# Altium schematic sheet SOC.SchDoc(SOC)
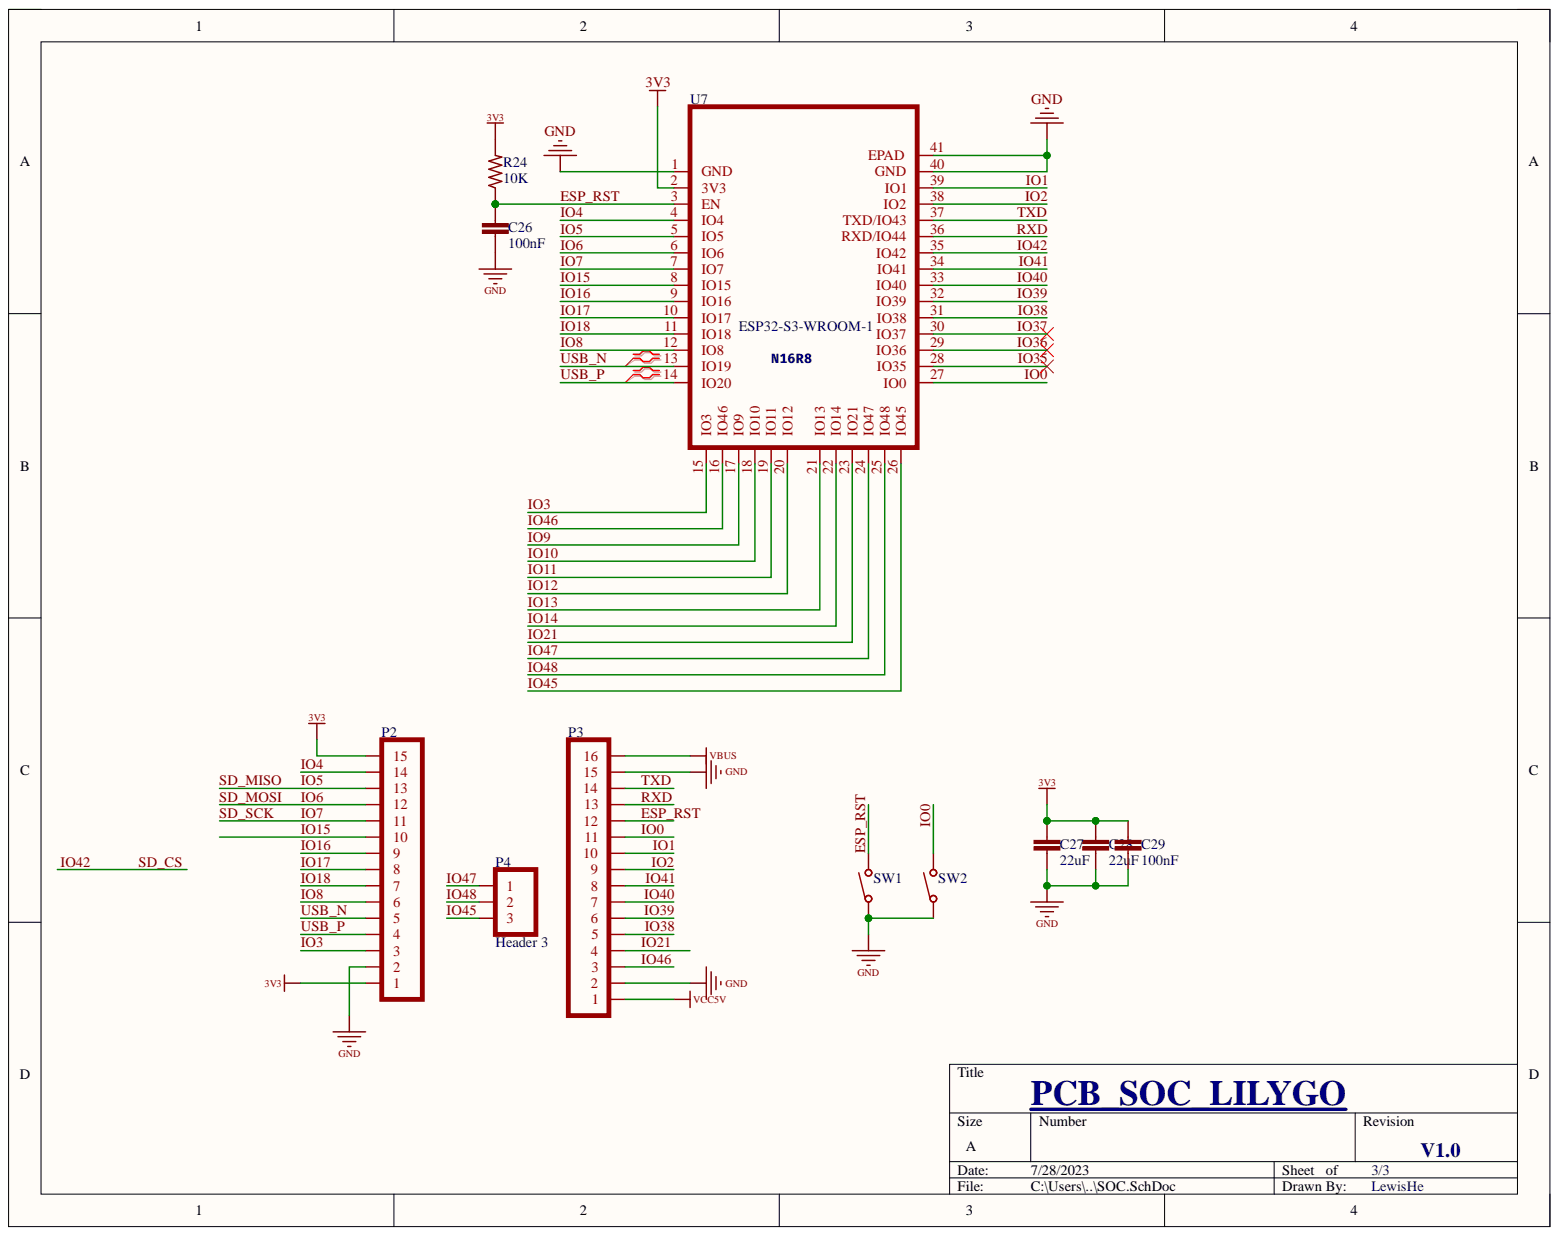
[diagram p0: SOC.SchDoc]

## Parts
C26  100nF  [C0402]
C27  22uF  [C0603]
C28  22uF  [C0603]
C29  100nF  [C0603]
P2  Header 15  [HDR1X15_插针]  (value not drawn)
P3  Header 16  [HDR1X16_插针]  (value not drawn)
P4  Header 3  [HDR1X3-排针]
R24  10K  [R0402]
SW1  TS342A2P-WZ  [SW-SMD_L4.0-W2.9-LS5.0]  (value not drawn)
SW2  TS342A2P-WZ  [SW-SMD_L4.0-W2.9-LS5.0]  (value not drawn)
U7  ESP32-S3-WROOM-1  [ESP32-S3-WROOM-1]

## Nets
3V3: C27.1 C28.1 C29.1 P2.1 P2.15 R24.2 U7.2(3V3)
GND: C26.1 C27.2 C28.2 C29.2 P2.2 P3.2 P3.15 SW1.1 SW2.1 U7.1(GND) U7.40(GND) U7.41(EPAD)
VBUS: P3.16
VCC5V: P3.1
ESP_RST: C26.2 P3.12 R24.1 SW1.2 U7.3(EN)
IO0: P3.11 SW2.2 U7.27(IO0)
IO1: P3.10 U7.39(IO1)
IO2: P3.9 U7.38(IO2)
IO3: P2.3 U7.15(IO3)
IO4: P2.14 U7.4(IO4)
IO5: P2.13 U7.5(IO5)
IO6: P2.12 U7.6(IO6)
IO7: P2.11 U7.7(IO7)
IO8: P2.6 U7.12(IO8)
IO9: U7.17(IO9)
IO10: U7.18(IO10)
IO11: U7.19(IO11)
IO12: U7.20(IO12)
IO13: U7.21(IO13)
IO14: U7.22(IO14)
IO15: P2.10 U7.8(IO15)
IO16: P2.9 U7.9(IO16)
IO17: P2.8 U7.10(IO17)
IO18: P2.7 U7.11(IO18)
IO21: P3.4 U7.23(IO21)
IO35: U7.28(IO35)
IO36: U7.29(IO36)
IO37: U7.30(IO37)
IO38: P3.5 U7.31(IO38)
IO39: P3.6 U7.32(IO39)
IO40: P3.7 U7.33(IO40)
IO41: P3.8 U7.34(IO41)
IO42: U7.35(IO42)
IO45: P4.3 U7.26(IO45)
IO46: P3.3 U7.16(IO46)
IO47: P4.1 U7.24(IO47)
IO48: P4.2 U7.25(IO48)
RXD: P3.13 U7.36(RXD/IO44)
TXD: P3.14 U7.37(TXD/IO43)
USB_N: P2.5 U7.13(IO19)
USB_P: P2.4 U7.14(IO20)

## Library data of the parts
C26-C29 = C0201: 0201  贴片电容; Component Kind: Standard; Library Name: 常用.SCHLIB
P2 = Header 15: 2.54mm 1x15 排针; Component Kind: Standard; Library Name: 常用.SCHLIB
P3 = Header 16: 2.54mm 1x16 排针; Component Kind: Standard; Library Name: 常用.SCHLIB
P4 = Header 3: 2.54mm 1x3 排针; Component Kind: Standard; Library Name: 常用.SCHLIB
R24 = R0201: Component Kind: Standard; Library Name: 常用.SCHLIB
SW1 SW2 = TS342A2P-WZ: 轻触开关 3*4*2 两脚小龟不带柱 250gf 黑色; Component Kind: Standard; Library Name: 常用.SCHLIB
U7 = ESP32-S3-WROOM-1: ESP32-S3-WROOM-1-N16R8; 系统型号: YLSMT102644; Component Kind: Standard; Library Name: 常用.SCHLIB
